# Altium schematic sheet Display.SchDoc(Display)
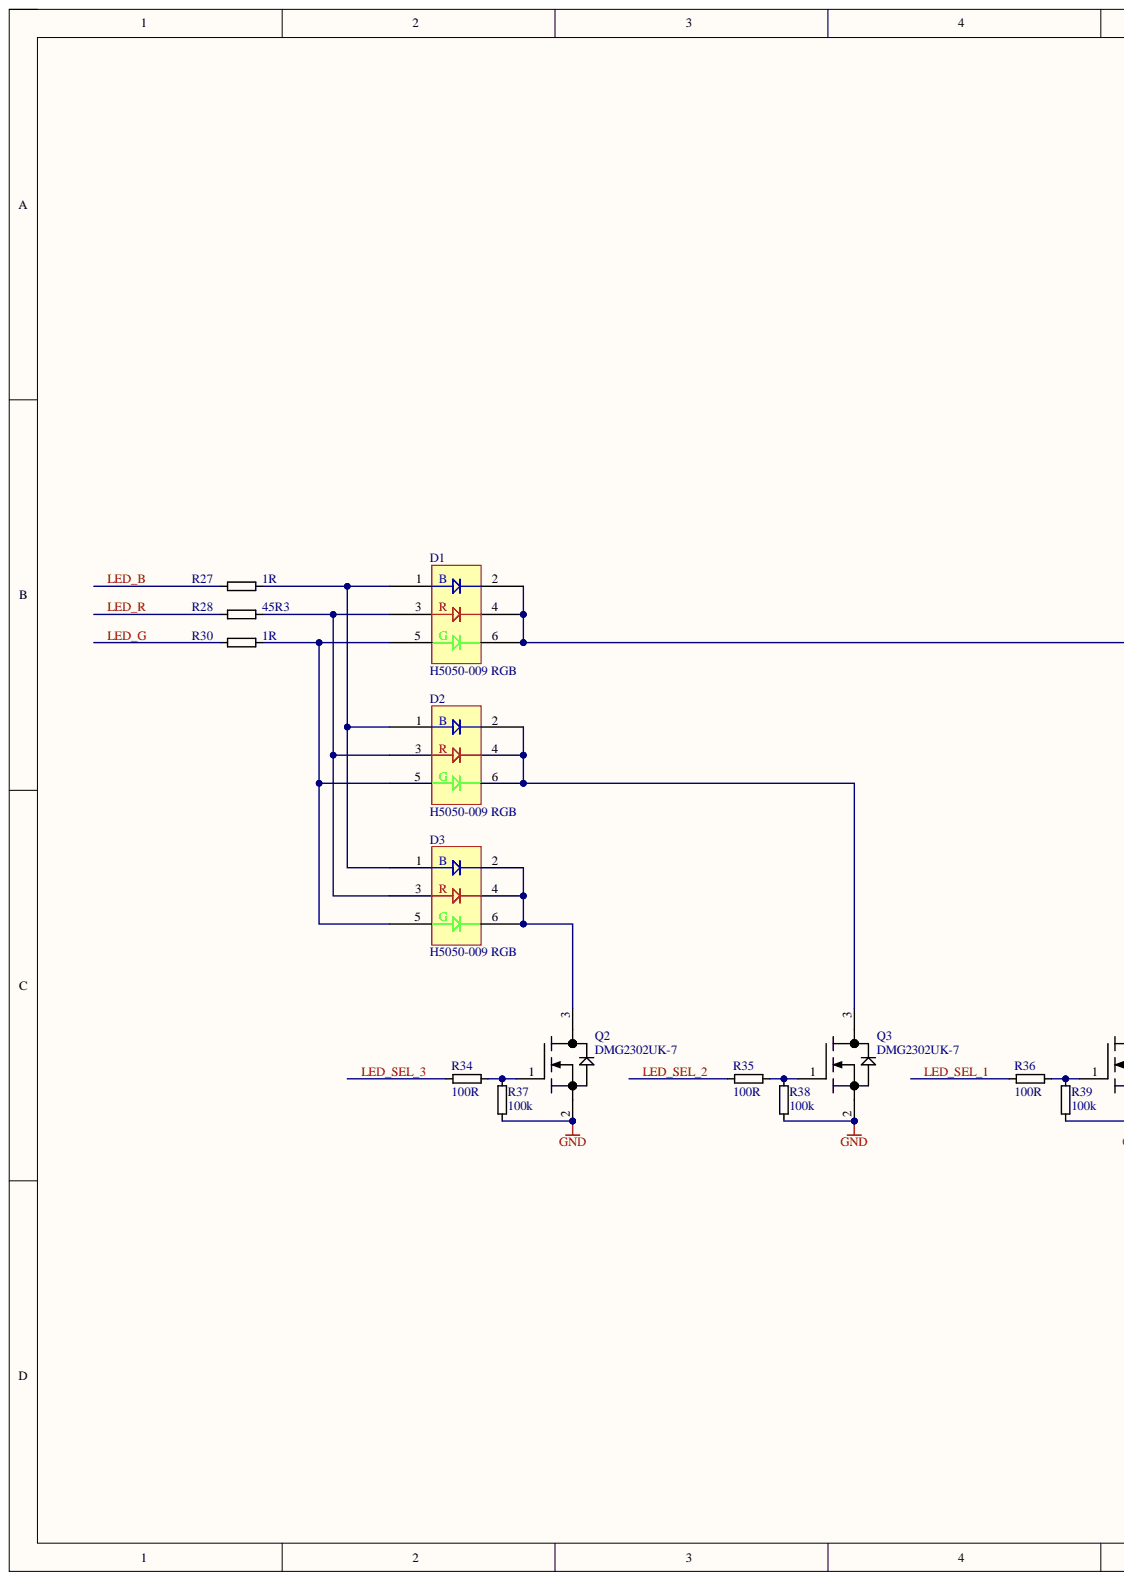
[diagram p0: Display.SchDoc, left]
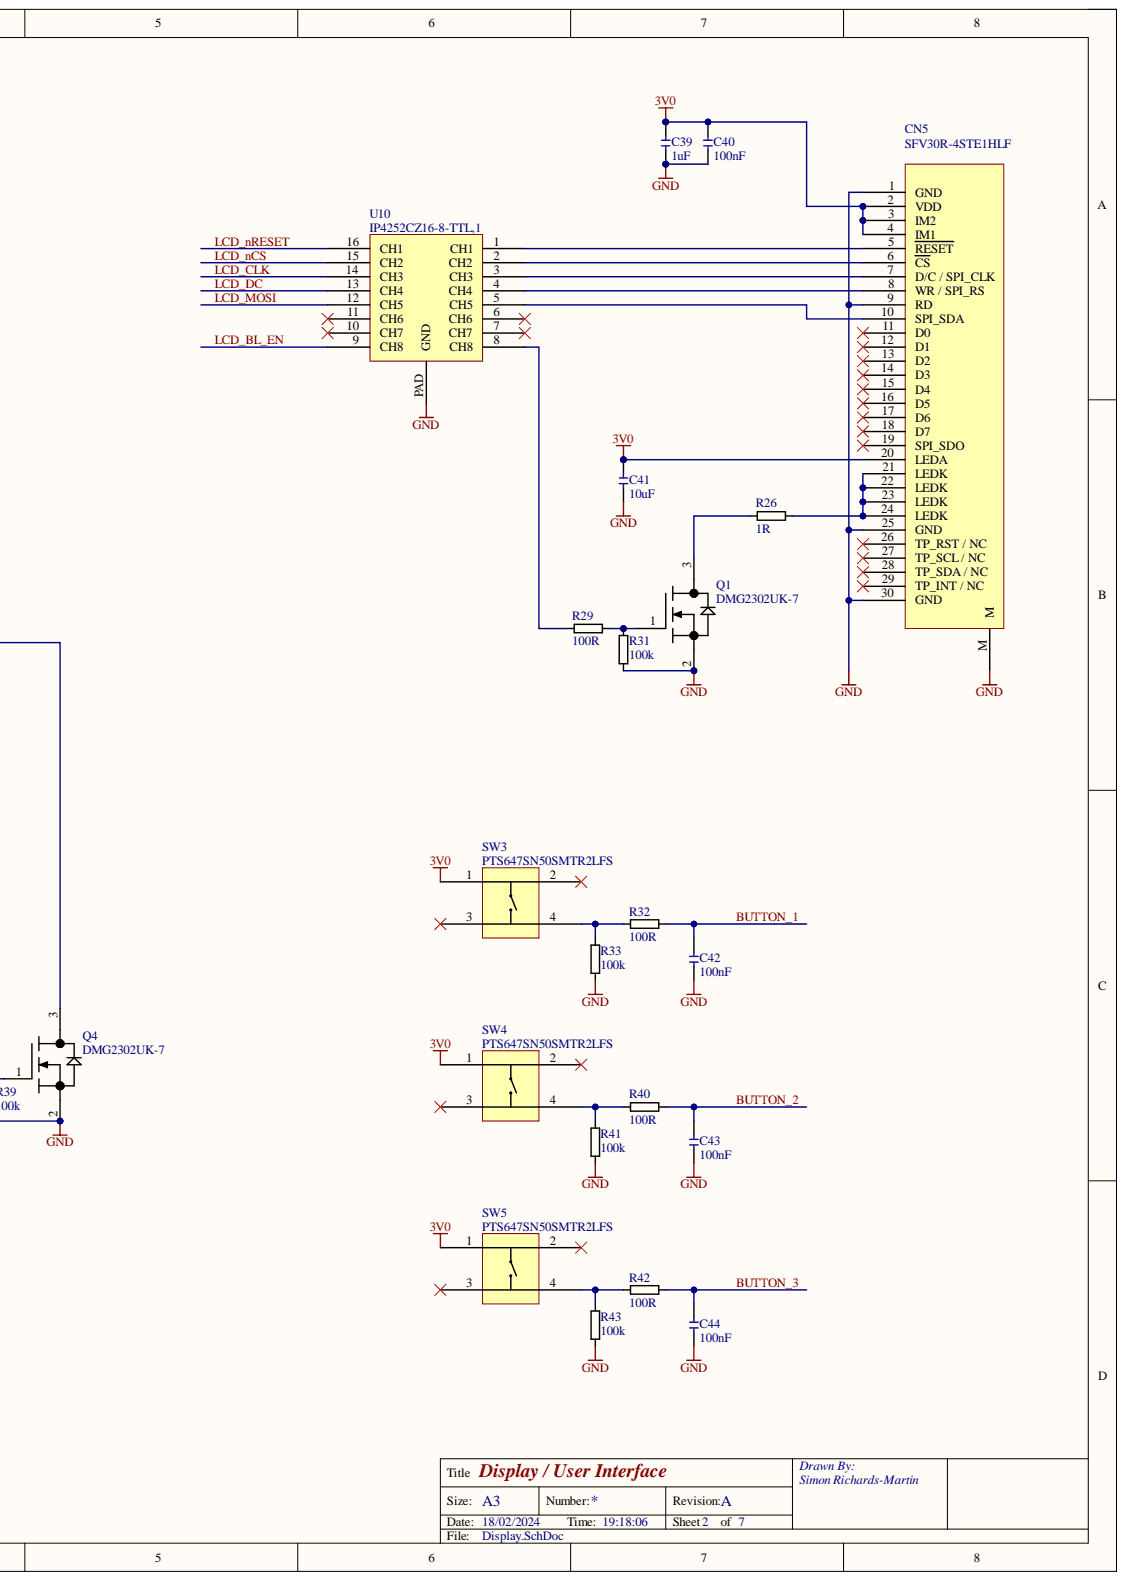
[diagram p1: Display.SchDoc, right]

## Parts
C39  1uF  [CHIP-0402]
C40  100nF  [CHIP-0402]
C41  10uF  [CHIP-0402]
C42  100nF  [CHIP-0402]
C43  100nF  [CHIP-0402]
C44  100nF  [CHIP-0402]
CN5  SFV30R-4STE1HLF  [Display - Extended FFC]
D1  H5050-009 RGB  [LED 5050]
D2  H5050-009 RGB  [LED 5050]
D3  H5050-009 RGB  [LED 5050]
Q1  DMG2302UK-7  [SOT23-3]
Q2  DMG2302UK-7  [SOT23-3]
Q3  DMG2302UK-7  [SOT23-3]
Q4  DMG2302UK-7  [SOT23-3]
R26  1R  [CHIP-0402]
R27  1R  [CHIP-0402]
R28  45R3  [CHIP-0402]
R29  100R  [CHIP-0402]
R30  1R  [CHIP-0402]
R31  100k  [CHIP-0402]
R32  100R  [CHIP-0402]
R33  100k  [CHIP-0402]
R34  100R  [CHIP-0402]
R35  100R  [CHIP-0402]
R36  100R  [CHIP-0402]
R37  100k  [CHIP-0402]
R38  100k  [CHIP-0402]
R39  100k  [CHIP-0402]
R40  100R  [CHIP-0402]
R41  100k  [CHIP-0402]
R42  100R  [CHIP-0402]
R43  100k  [CHIP-0402]
SW3  PTS647SN50SMTR2LFS  [PTS647SN50SMTR2LFS]
SW4  PTS647SN50SMTR2LFS  [PTS647SN50SMTR2LFS]
SW5  PTS647SN50SMTR2LFS  [PTS647SN50SMTR2LFS]
U10  IP4252CZ16-8-TTL,1  [SON40P140X55_HS-17N]

## Nets
3V0: C39.1 C40.1 C41.1 CN5.2(VDD) CN5.3(IM2) CN5.4(IM1) CN5.20(LEDA) SW3.1 SW4.1 SW5.1
GND: C39.2 C40.2 C41.2 C42.2 C43.2 C44.2 CN5.1(GND) CN5.9(RD) CN5.25(GND) CN5.30(GND) CN5.M Q1.2 Q2.2 Q3.2 Q4.2 R31.1 R33.1 R37.1 R38.1 R39.1 R41.1 R43.1 U10.PAD(GND)
BUTTON_1: C42.1 R32.2
BUTTON_2: C43.1 R40.2
BUTTON_3: C44.1 R42.2
LCD_BL_EN: U10.9(CH8)
LCD_CLK: U10.14(CH3)
LCD_DC: U10.13(CH4)
LCD_MOSI: U10.12(CH5)
LCD_nCS: U10.15(CH2)
LCD_nRESET: U10.16(CH1)
LED_B: R27.1
LED_G: R30.1
LED_R: R28.1
LED_SEL_1: R36.1
LED_SEL_2: R35.1
LED_SEL_3: R34.1
NetCN5_5: CN5.5(RESET) U10.1(CH1)
NetCN5_6: CN5.6(CS) U10.2(CH2)
NetCN5_7: CN5.7(D/C_/_SPI_CLK) U10.3(CH3)
NetCN5_8: CN5.8(WR_/_SPI_RS) U10.4(CH4)
NetCN5_10: CN5.10(SPI_SDA) U10.5(CH5)
NetCN5_21: CN5.21(LEDK) CN5.22(LEDK) CN5.23(LEDK) CN5.24(LEDK) R26.2
NetD1_1: D1.1 D2.1 D3.1 R27.2
NetD1_2: D1.2 D1.4 D1.6 Q4.3
NetD1_3: D1.3 D2.3 D3.3 R28.2
NetD1_5: D1.5 D2.5 D3.5 R30.2
NetD2_2: D2.2 D2.4 D2.6 Q3.3
NetD3_2: D3.2 D3.4 D3.6 Q2.3
NetQ1_1: Q1.1 R29.2 R31.2
NetQ1_3: Q1.3 R26.1
NetQ2_1: Q2.1 R34.2 R37.2
NetQ3_1: Q3.1 R35.2 R38.2
NetQ4_1: Q4.1 R36.2 R39.2
NetR29_1: R29.1 U10.8(CH8)
NetR32_1: R32.1 R33.2 SW3.4
NetR40_1: R40.1 R41.2 SW4.4
NetR42_1: R42.1 R43.2 SW5.4

Not connected: CN5 11(D0) 12(D1) 13(D2) 14(D3) 15(D4) 16(D5) 17(D6) 18(D7) 19(SPI_SDO) 26(TP_RST_/_NC) 27(TP_SCL_/_NC) 28(TP_SDA_/_NC) 29(TP_INT_/_NC); SW3 2 3; SW4 2 3; SW5 2 3; U10 6(CH6) 7(CH7) 10(CH7) 11(CH6)

## Library data of the parts
C39 = Capacitor 1uF 50V 0402 X5R: Manufacturer: Murata; MPN: GRM155R61H105KE05D; Component Kind: Standard; Description: Capacitor 1uF 50V 0402 X5R; Library Name: Paramotor Sensor Main.SchLib
C40 C42-C44 = Capacitor 100nF 50V 0402 X5R: Manufacturer: Murata; MPN: GRM155R61H104KE14D; Component Kind: Standard; Description: Capacitor 100nF 50V 0402 X5R; Library Name: Paramotor Sensor Main.SchLib
C41 = Capacitor 10uF 10V 0402 X5R: Manufacturer: Murata; MPN: GRM155R61A106ME11D; Component Kind: Standard; Description: Capacitor 10uF 10V 0402 X5R; Library Name: Paramotor Sensor Main.SchLib
CN5 = DISPLAY: FFC 30-pin Top Contact 0.5mm Pitch; Manufacturer: Amphenol-FCI; Component Kind: Standard; MPN: SFV30R-4STE1HLF; Library Name: Paramotor Sensor Main.SchLib
D1-D3 = H5050-009 RGB: RGB LED 5050; Component Kind: Standard; MPN: H5050-009 RGB; Library Name: Paramotor Sensor Main.SchLib
Q1-Q4 = DMG2302UK-7: MOSFET N 2.8A 20V 90mR SOT23-3; Manufacturer: Diodes Inc; Component Kind: Standard; MPN: DMG2302UK-7; Library Name: Paramotor Sensor Main.SchLib
R26 R27 R30 = Resistor 1R 1% 0402: Manufacturer: Yageo; MPN: AC0402FR-071RL; Component Kind: Standard; Description: Resistor 1R 1% 0402; Library Name: Paramotor Sensor Main.SchLib
R28 = Resistor 45.3R 1% 0402: Manufacturer: Yageo; MPN: RC0402FR-0745R3L; Component Kind: Standard; Description: Resistor 45.3R 1% 0402; Library Name: Paramotor Sensor Main.SchLib
R29 R32 R34-R36 R40 R42 = Resistor 100R 1% 0402: Manufacturer: Yageo; MPN: AC0402FR-07100RL; Component Kind: Standard; Description: Resistor 100R 1% 0402; Library Name: Paramotor Sensor Main.SchLib
R31 R33 R37-R39 R41 R43 = Resistor 100k 1% 0402: Manufacturer: Yageo; MPN: RC0402FR-07100KL; Component Kind: Standard; Description: Resistor 100k 1% 0402; Library Name: Paramotor Sensor Main.SchLib
SW3-SW5 = PTS647SN50SMTR2LFS: Tactile Switch Vert 5mm H 4.5x4.5mm; Manufacturer: C&K Switches; Component Kind: Standard; MPN: PTS647SN50SMTR2LFS; Library Name: Paramotor Sensor Main.SchLib
U10 = IP4252CZ16-8-TTL,1: EMI Filter + ESD 12pF 40Ohms 8CH; Manufacturer: Nexperia; Component Kind: Standard; MPN: IP4252CZ16-8-TTL,1; Library Name: Paramotor Sensor Main.SchLib
